# Altium schematic sheet Sheet1.SchDoc
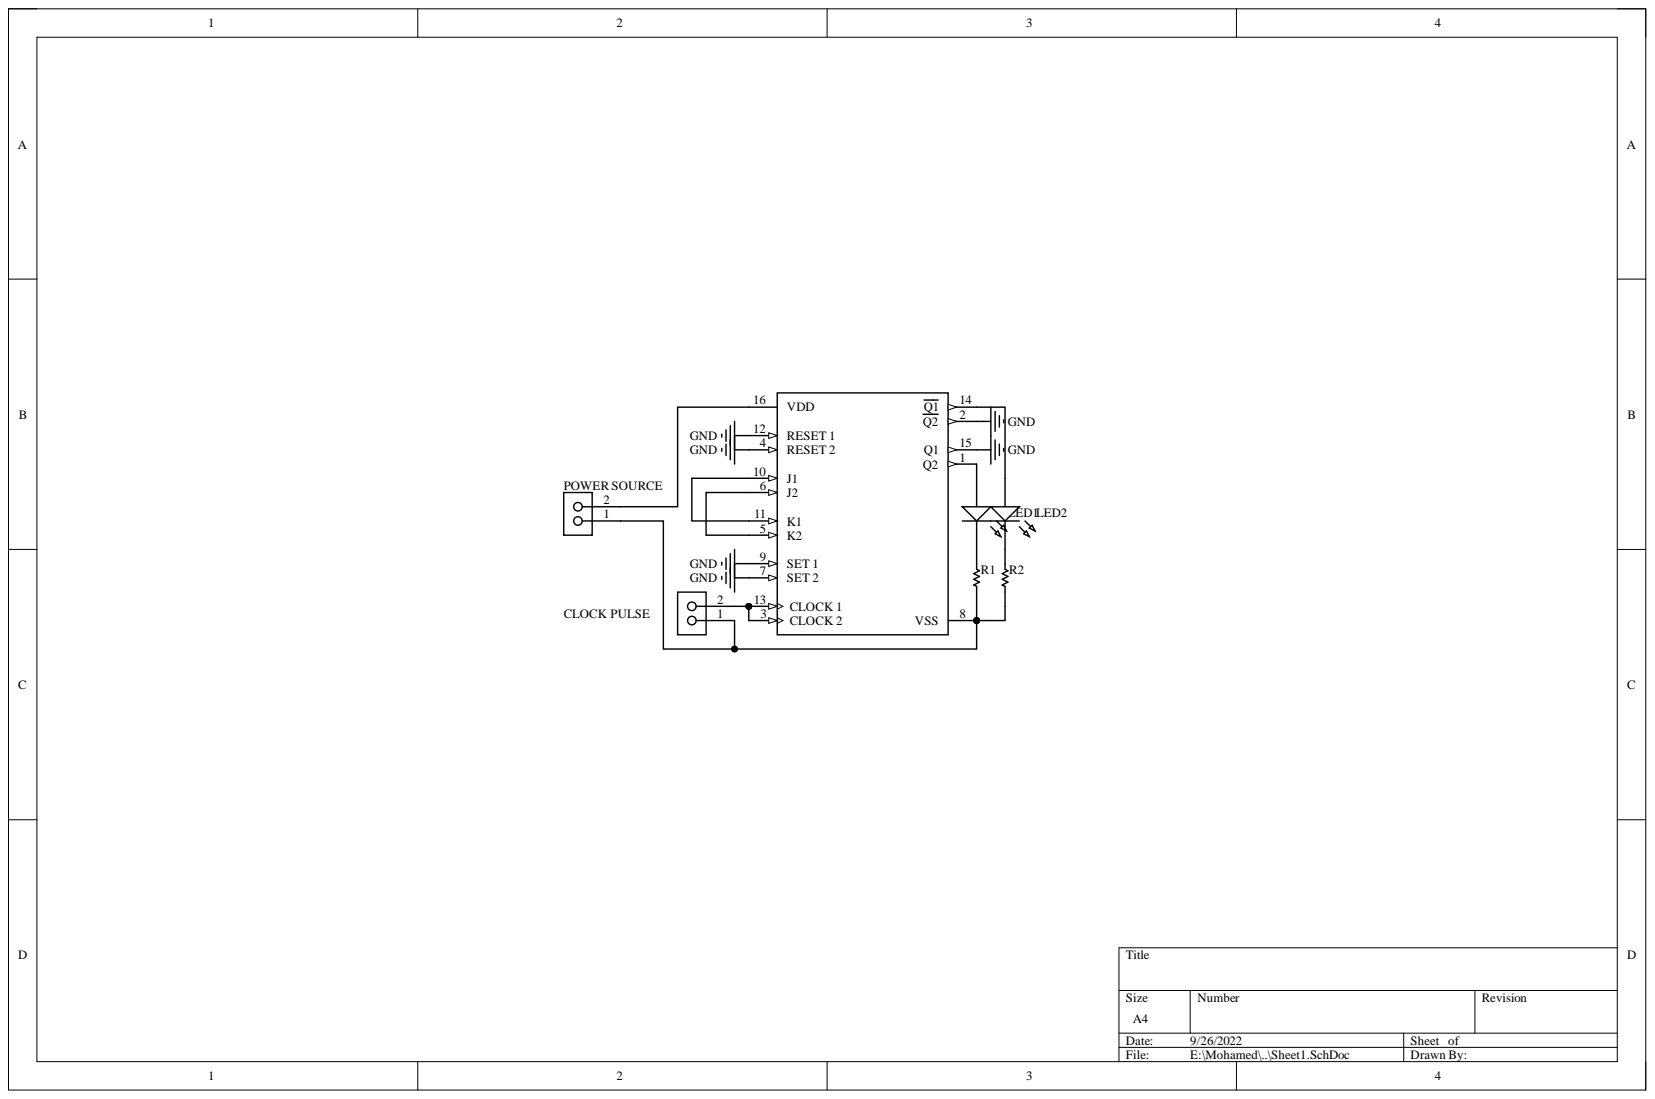
[diagram p0: Sheet1.SchDoc]

## Parts
CLOCK_PULSE  22-27-2021  [PIN]  (value not drawn)
LED1  151051BS04000  [BLUE LED]  (value not drawn)
LED2  151051BS04000  [BLUE LED]  (value not drawn)
POWER_SOURCE  22-27-2021  [PIN]  (value not drawn)
R1  CRCW1210330RJNEA  [330OHM RES]  (value not drawn)
R2  CRCW1210330RJNEA  [330OHM RES]  (value not drawn)
  CD4027BE  [JK FLIP FLOP]  (value not drawn)

## Nets
GND: .2(Q2) .4(RESET_2) .7(SET_2) .9(SET_1) .12(RESET_1) .15(Q1)
Net_1: LED1.2 .1(Q2)
Net_3: CLOCK_PULSE.2 .3(CLOCK_2) .13(CLOCK_1)
Net_5: .5(K2) .6(J2)
Net_8: CLOCK_PULSE.1 POWER_SOURCE.1 R1.1 R2.1 .8(VSS)
Net_10: .10(J1) .11(K1)
Net_14: LED2.2 .14(Q1)
Net_16: POWER_SOURCE.2 .16(VDD)
NetLED1_1: LED1.1 R1.2
NetLED2_1: LED2.1 R2.2

## Library data of the parts
CLOCK_PULSE POWER_SOURCE = PIN: Male Header, Pitch 2.54 mm, 1 x 2 Position, Height 11.7 mm, Tail Length 3.56 mm, 0 to 75 degC, RoHS, Bulk; Manufacturer: Molex; PartNumber: 0022272021; Current - Max: 4A; No. of Positions: 2; No. of Rows: 1; Package Version: Rev. BC, 02/2012; Pitch: 2.54mm; Voltage - Max: 250V; Component Kind: Standard; Contact material: Tinplatedbrass; Current rating: 4A; Datasheet URL: http://www.molex.com/pdm_docs/sd/022272021_sd.pdf; Datasheet Version: Rev. F, 06/2009; Housing material: Nylon(UL94V-0); Library Name: Schlib1.SchLib; Manufacturer URL: http://www.molex.com/; Mounting Technology: ThroughHole; Operating temperature: 0°Cto+75°C; Orientation: Vertical; Package Description: 2-Leads Header, Body 5.08 x 5.8 mm, Pitch 2.54 mm; Package Reference: 22-27-2021; Voltage rating: 250V
LED1 LED2 = BLUE LED: THT LED round mono-color color lens, WL-TMRW, Blue; Manufacturer: Wuerth Elektronik; Component Kind: Standard; ComponentLink1Description: Manufacturer URL; ComponentLink1URL: http://www.we-online.com; ComponentLink2Description: Datasheet; ComponentLink2URL: http://katalog.we-online.de/pbs/datasheet/7448042001.pdfhttp://katalog.we-online.de/pbs/datasheet/7448042001.pdfhttp://katalog.we-online.de/pbs/datasheet/7448042001.pdfhttp://katalog.we-online.de/pbs/datasheet/151051BS04000.pdf; Emitting color: Blue; Library Name: Schlib1.SchLib; PackageReference: Type 5mm; PartNumber: 151051BS04000
R1 R2 = 330OHM RES: Manufacturer: Vishay Dale; Component Kind: Standard; Library Name: Schlib1.SchLib; Part Number: CRCW1210330RJNEA
 = JK FLIP FLOP: IC FF JK TYPE DUAL 1BIT 16DIP; Clock Edge Trigger Type: Positive Edge; Frequency: 24MHz; High Level Output Current: -4.2mA; Input Capacitance: 5pF; Input Type: SINGLE-ENDED; Logic Function: Flip-Flop; Low Level Output Current: 4.2mA; Max Supply Voltage: 18V; Min Supply Voltage: 3V; Number of Bits: 2; Number of Bits per Element: 1; Operating Supply Voltage: 3.3V; Output Current: 6.8mA; Polarity: Non-Inverting; Power Dissipation: 500mW; Propagation Delay: 300ns; Quiescent Current: 20uA; Turn-On Delay Time: 45ns; Case/Package: PDIP; Component Kind: Standard; Contact Plating: Gold; Height: 4.57mm; Lead Free: Lead Free; Length: 19.3mm; Library Name: Schlib1.SchLib; Max Frequency: 24MHz; Max Operating Temperature: 125°C; Min Operating Temperature: -55°C; Mount: Through Hole; Number of Circuits: 2; Number of Elements: 2; Number of Input Lines: 2; Number of Output Lines: 1; Number of Pins: 16; Package Quantity: 25; Packaging: Rail/Tube; Radiation Hardening: No; REACH SVHC: No SVHC; RoHS Compliant: Yes; Series: 4000B; Technology: CMOS; Weight: 0.03357oz; Width: 6.35mm
